# Altium schematic sheet Sheet1.SchDoc
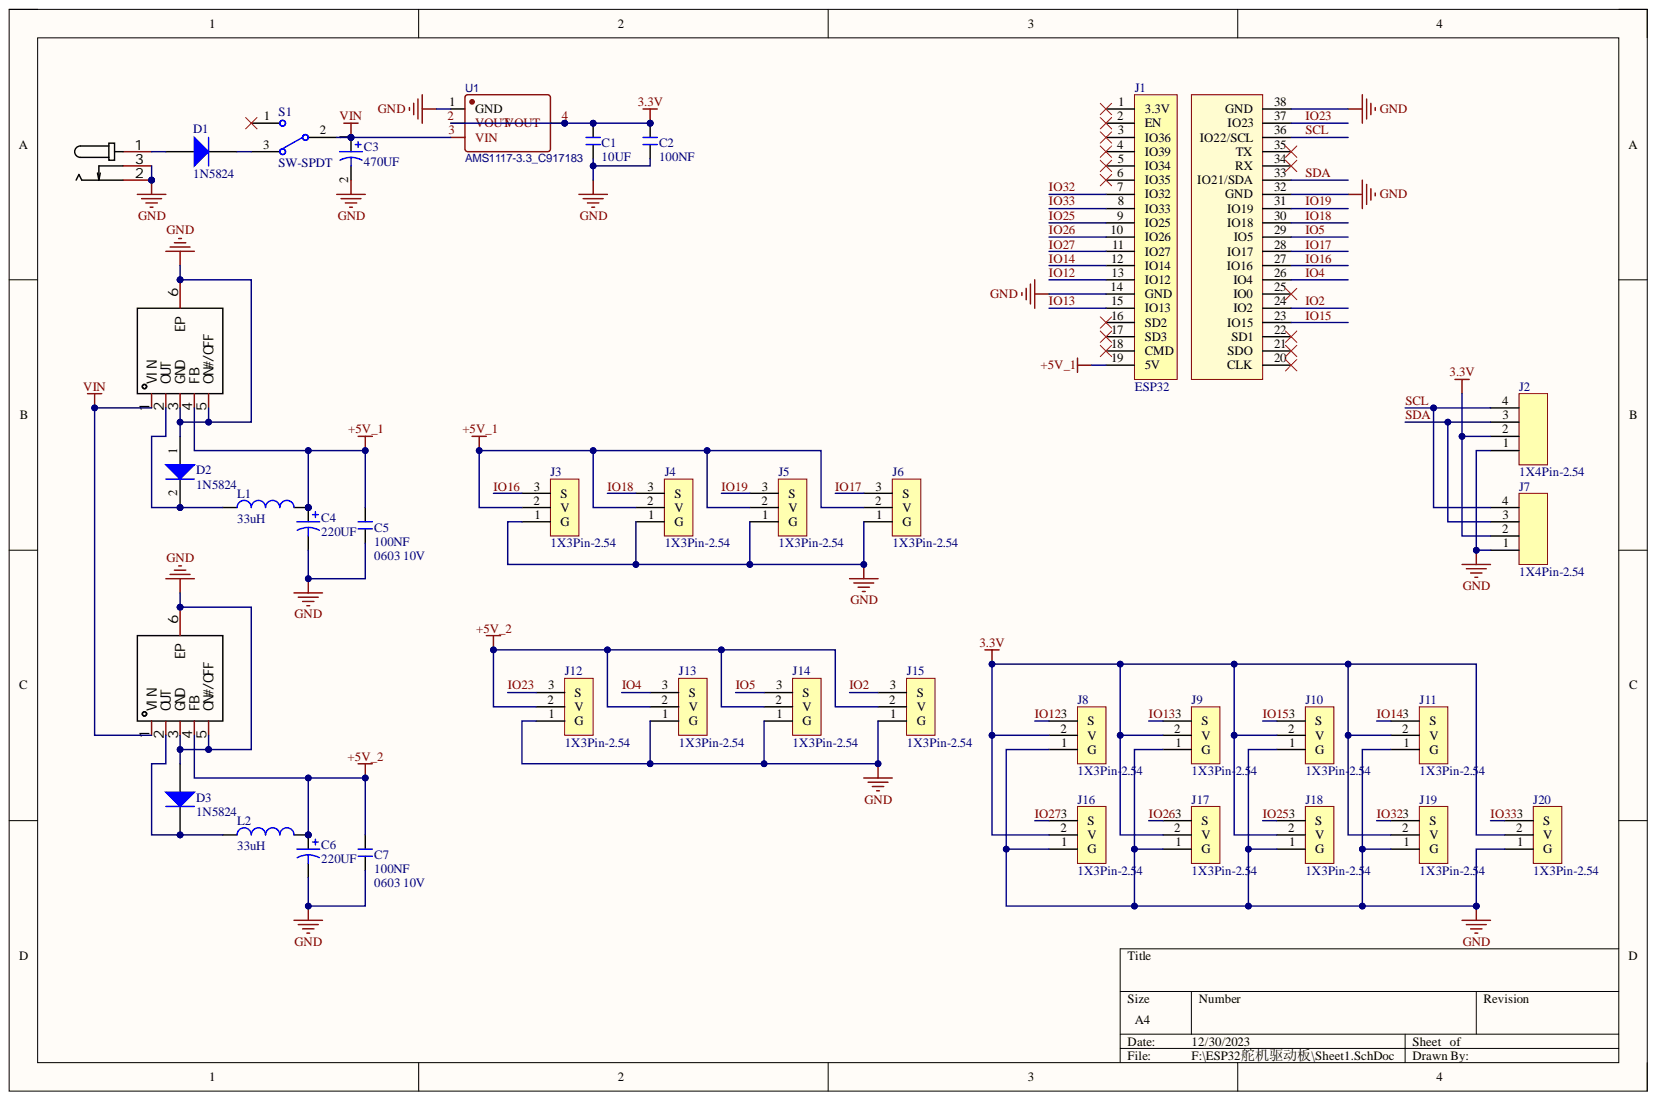
[diagram p0: Sheet1.SchDoc]

## Parts
C1  10UF  [C 0603_L]
C2  100NF  [C 0603_L]
C3  value 470UF  [6.3*7.7MM 电解电容]  (hidden comment: Cap Pol2)
C4  value 220UF  [6.3*7.7MM 电解电容]  (hidden comment: Cap Pol2)
C5  100NF  [C 0603_L]
C6  value 220UF  [6.3*7.7MM 电解电容]  (hidden comment: Cap Pol2)
C7  100NF  [C 0603_L]
D1  1N5824  [SS54 4.5*3.5MM 二极管]
D2  1N5824  [SS54 4.5*3.5MM 二极管]
D3  1N5824  [SS54 4.5*3.5MM 二极管]
DC1  DC-005-A200  [DC-IN-TH_DC-005-A200]  (value not drawn)
J1  ESP32  [ESP32底座]
J2  1X4Pin-2.54  [1X4-2.54MM]
J3  1X3Pin-2.54  [1X3-2.54MM 全方口]
J4  1X3Pin-2.54  [1X3-2.54MM 全方口]
J5  1X3Pin-2.54  [1X3-2.54MM 全方口]
J6  1X3Pin-2.54  [1X3-2.54MM 全方口]
J7  1X4Pin-2.54  [1X3-2.54MM 全方口]
J8  1X3Pin-2.54  [1X3-2.54MM 全方口]
J9  1X3Pin-2.54  [1X3-2.54MM 全方口]
J10  1X3Pin-2.54  [1X3-2.54MM 全方口]
J11  1X3Pin-2.54  [1X3-2.54MM 全方口]
J12  1X3Pin-2.54  [1X3-2.54MM 全方口]
J13  1X3Pin-2.54  [1X3-2.54MM 全方口]
J14  1X3Pin-2.54  [1X3-2.54MM 全方口]
J15  1X3Pin-2.54  [1X3-2.54MM 全方口]
J16  1X3Pin-2.54  [1X3-2.54MM 全方口]
J17  1X3Pin-2.54  [1X3-2.54MM 全方口]
J18  1X3Pin-2.54  [1X3-2.54MM 全方口]
J19  1X3Pin-2.54  [1X3-2.54MM 全方口]
J20  1X3Pin-2.54  [1X3-2.54MM 全方口]
L1  value 33uH  [L 12*12*7 电感]  (hidden comment: Inductor)
L2  value 33uH  [L 12*12*7 电感]  (hidden comment: Inductor)
S1  SW-SPDT  [SW-3P 5.08MM 直立式]
U1  AMS1117-3.3_C917183  [SOT223_N]
U2  LM2596S-5.0_C347421  [TO-263-5_L10.2-W8.9-P1.70-BR]  (value not drawn)
U3  LM2596S-5.0_C347421  [TO-263-5_L10.2-W8.9-P1.70-BR]  (value not drawn)

## Nets
3.3V: C1.2 C2.2 J2.2 J7.2 J8.2 J9.2 J10.2 J11.2 J16.2 J17.2 J18.2 J19.2 J20.2 U1.2(VOUT) U1.4(VOUT)
+5V_1: C4.1 C5.2 J1.19(5V) J3.2 J4.2 J5.2 J6.2 L1.2 U2.4(FB)
+5V_2: C6.1 C7.2 J12.2 J13.2 J14.2 J15.2 L2.2 U3.4(FB)
GND: C1.1 C2.1 C3.2 C4.2 C5.1 C6.2 C7.1 D2.1 D3.1 DC1.2 DC1.3 J1.14(GND) J1.32(GND) J1.38(GND) J2.1 J3.1 J4.1 J5.1 J6.1 J7.1 J8.1 J9.1 J10.1 J11.1 J12.1 J13.1 J14.1 J15.1 J16.1 J17.1 J18.1 J19.1 J20.1 U1.1(GND) U2.3(GND) U2.5(ON#/OFF) U2.6(EP) U3.3(GND) U3.5(ON#/OFF) U3.6(EP)
VIN: C3.1 S1.2 U1.3(VIN) U2.1(VIN) U3.1(VIN)
IO2: J1.24(IO2) J15.3
IO4: J1.26(IO4) J13.3
IO5: J1.29(IO5) J14.3
IO12: J1.13(IO12) J8.3
IO13: J1.15(IO13) J9.3
IO14: J1.12(IO14) J11.3
IO15: J1.23(IO15) J10.3
IO16: J1.27(IO16) J3.3
IO17: J1.28(IO17) J6.3
IO18: J1.30(IO18) J4.3
IO19: J1.31(IO19) J5.3
IO23: J1.37(IO23) J12.3
IO25: J1.9(IO25) J18.3
IO26: J1.10(IO26) J17.3
IO27: J1.11(IO27) J16.3
IO32: J1.7(IO32) J19.3
IO33: J1.8(IO33) J20.3
SCL: J1.36(IO22/SCL) J2.4 J7.4
SDA: J1.33(IO21/SDA) J2.3 J7.3
NetD1_1: D1.1 DC1.1
NetD1_2: D1.2 S1.3
NetD2_2: D2.2 L1.1 U2.2(OUT)
NetD3_2: D3.2 L2.1 U3.2(OUT)

Not connected: J1 1(3.3V) 2(EN) 3(IO36) 4(IO39) 5(IO34) 6(IO35) 16(SD2) 17(SD3) 18(CMD) 20(CLK) 21(SDO) 22(SD1) 25(IO0) 34(RX) 35(TX); S1 1

## Library data of the parts
C1 C2 C5 C7 = C 0603: 贴片电容; Component Kind: Standard; Library Name: My schematic lib.SCHLIB
C3 C4 C6 = Cap L6.3 W6.6: 有极性电容; Component Kind: Standard; LatestRevisionDate: 17-Jul-2002; LatestRevisionNote: Re-released for DXP Platform.; Library Name: My schematic lib.SCHLIB; PackageDescription: Polarized Capacitor; 2 Leads; PackageReference: POLAR0.8; Published: 8-Jun-2000; Publisher: Altium Limited
D1-D3 = Diode: 肖特基 20V 3A; Code_JEDEC: DO-214-AB; Component Kind: Standard; LatestRevisionDate: 17-Jul-2002; LatestRevisionNote: Re-released for DXP Platform.; Library Name: My schematic lib.SCHLIB; PackageDescription: DO-214-AB/SMC; 2 C-Bend Leads; Body 7.9 x 5.9 mm, inc. leads (LxW); PackageReference: SMC; PackageVersion: Sep-1996; Published: 8-Jun-2000; Publisher: Altium Limited
DC1 = DC电源接口: Manufacturer: XUNPU(讯普); Add into BOM: yes; Component Kind: Standard; Convert to PCB: yes; Datasheet: https://atta.szlcsc.com/upload/public/pdf/source/20200812/C720557_2286DFCD6C75B71AF905731F627ABF3A.pdf; Device: DC-005-A200; JLCPCB Part Class: Extended Part; LCSC Part Name: DC-005-A200; Library Name: My schematic lib.SCHLIB; Manufacturer Part: DC-005-A200; Name: DC-005-A200; Supplier: LCSC; Supplier Footprint: 14×9.0×11.0mm; Supplier Part: C720557; Symbol: DC-005-A200
J1 = ESP32 开发板底座: Component Kind: Standard; Library Name: My schematic lib.SCHLIB
L1 L2 = L: 功率电感; Code_IEC: 1005; Code_JEITA: 0402; Component Kind: Standard; LatestRevisionDate: 29-May-2009; LatestRevisionNote: IPC-7351 Footprint Added.; Library Name: My schematic lib.SCHLIB; PackageDescription: Chip Inductor; PackageReference: 0402-A; Published: 8-Jun-2000; Publisher: Altium Limited
S1 = SW-SPDT: 拨码开关; Component Kind: Standard; ComponentLink1Description: Manufacturer Link; ComponentLink1URL: http://www.apem.com; DatasheetVersion: 05-Dec-2000; LatestRevisionDate: 17-Dec-2003; LatestRevisionNote: Schematic revised, PCB Footprint replaced.; Library Name: My schematic lib.SCHLIB; PackageDescription: Switch; 4 Leads; PackageReference: TL36WW15050; Published: 24-Mar-1999; Publisher: Altium Limited
U1 = AMS1117-3.3_C917183: 1117 3.3v; BOM_Manufacturer: JSMSEMI; Contributor: LCSC; BOM_JLCPCB Part Class: Extended Part; BOM_Manufacturer Part: AMS1117-3.3; BOM_Supplier: LCSC; BOM_Supplier Part: C917183; Component Kind: Standard; Library Name: My schematic lib.SCHLIB; spicePre: U; spiceSymbolName: AMS1117-3.3_C917183
U2 U3 = LM2596S-5.0_C347421: Manufacturer: UMW(友台半导体); Add into BOM: yes; Function: 降压型; Input Voltage: 40V; Number of Outputs: 1; Output Current (Max): 3A; Output Type: 固定; Output Voltage: 5V; Switch tube (built-in/external): 内置; Switching Frequency: 150kHz; Synchronous Rectification: 否; Topology: 降压式; Component Kind: Standard; Convert to PCB: yes; Datasheet: https://atta.szlcsc.com/upload/public/pdf/source/20230506/0930E65C3A8B7B120C8A4D8E5A8AC327.pdf; Device: LM2596S-5.0_C347421; JLCPCB Part Class: Extended Part; LCSC Part Name: LM2596S-5.0; Library Name: My schematic lib.SCHLIB; Manufacturer Part: LM2596S-5.0; Operating Temperature: -40℃~+125℃@(TA); Supplier: LCSC; Supplier Footprint: TO-263-5; Supplier Part: C347421; Symbol: LM2596S-5.0
J2 J7 = 1X4Pin-2.54: Component Kind: Standard; Library Name: My schematic lib.SCHLIB
J3-J6 J8-J20 = 1X3Pin-2.54: Component Kind: Standard; Library Name: My schematic lib.SCHLIB
